# Altium schematic sheet MotorCTRL.SchDoc(MotorCTRL)
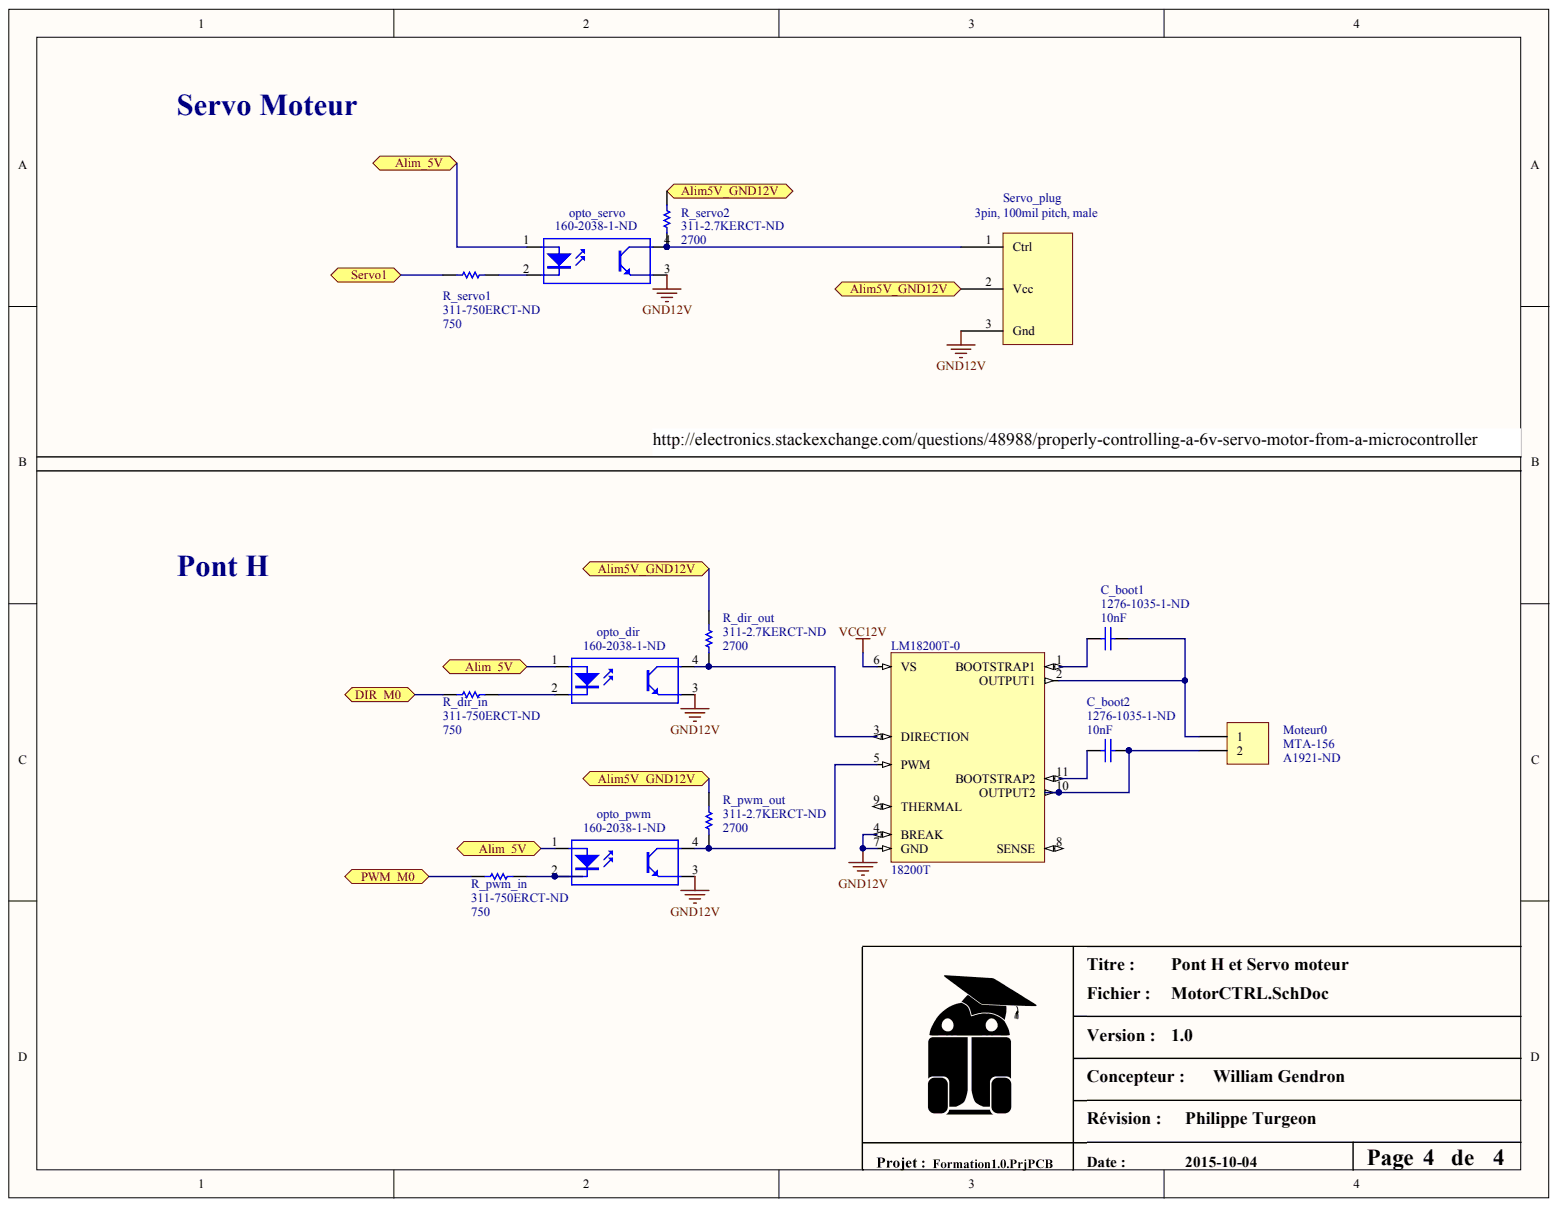
[diagram p0: MotorCTRL.SchDoc]

## Parts
C_boot1  value 10nF  Supplier Part Number 1: 1276-1035-1-ND  [c1206]  (hidden comment: 10nf - 1276-1035-1-ND)
C_boot2  value 10nF  Supplier Part Number 1: 1276-1035-1-ND  [c1206]  (hidden comment: 10nf - 1276-1035-1-ND)
LM18200T-0  18200T  [18200T]
Moteur0  A1921-ND  value MTA-156  [2PIN_396]
opto_dir  160-2038-1-ND  [Opto_simple]
opto_pwm  160-2038-1-ND  [Opto_simple]
opto_servo  160-2038-1-ND  [Opto_simple]
R_dir_in  value 750  Supplier Part Number 1: 311-750ERCT-ND  [8-1206]  (hidden comment: 220 ohms - 311-220ERCT-ND)
R_dir_out  value 2700  Supplier Part Number 1: 311-2.7KERCT-ND  [8-1206]  (hidden comment: 220 ohms - 311-220ERDKR-ND)
R_pwm_in  value 750  Supplier Part Number 1: 311-750ERCT-ND  [8-1206]  (hidden comment: 220 ohms - 311-220ERCT-ND)
R_pwm_out  value 2700  Supplier Part Number 1: 311-2.7KERCT-ND  [8-1206]  (hidden comment: 220ohms - 311-220ERDKR-ND)
R_servo1  value 750  [C1206]  (hidden comment: 220)
R_servo2  value 2700  Supplier part number 1: 311-2.7KERCT-ND  [C1206]  (hidden comment: 220)
Servo_plug  3pin, 100mil pitch, male  [ServoPin]

## Ports
ALIM5V_GND12V, ALIM_5V, DIR_M0, PWM_M0, SERVO1

## Nets
GND12V: LM18200T-0.4(BREAK) LM18200T-0.7(GND) opto_dir.3 opto_pwm.3 opto_servo.3 Servo_plug.3
VCC12V: LM18200T-0.6(VS)
DIR_M0: R_dir_in.1 ; port DIR_M0
PWM_M0: R_pwm_in.1 ; port PWM_M0
NetC_boot1_1: C_boot1.1 LM18200T-0.1(BOOTSTRAP1)
NetC_boot1_2: C_boot1.2 LM18200T-0.2(OUTPUT1) Moteur0.1
NetC_boot2_1: C_boot2.1 LM18200T-0.11(BOOTSTRAP2)
NetC_boot2_2: C_boot2.2 LM18200T-0.10(OUTPUT2) Moteur0.2
NetLM18200T-0_3: LM18200T-0.3(DIRECTION) opto_dir.4 R_dir_out.1
NetLM18200T-0_5: LM18200T-0.5(PWM) opto_pwm.4 R_pwm_out.2
Netopto_dir_1: opto_dir.1 opto_pwm.1 opto_servo.1 ; port ALIM_5V
Netopto_dir_2: opto_dir.2 R_dir_in.2
Netopto_pwm_2: opto_pwm.2 R_pwm_in.2
Netopto_servo_2: opto_servo.2 R_servo1.2
Netopto_servo_4: opto_servo.4 R_servo2.1 Servo_plug.1
NetR_dir_out_2: R_dir_out.2 R_pwm_out.1 R_servo2.2 Servo_plug.2 ; port ALIM5V_GND12V
NetR_servo1_1: R_servo1.1 ; port SERVO1

Not connected: LM18200T-0 8(SENSE) 9(THERMAL)

## Library data of the parts
C_boot1 C_boot2 = Cap: Capacitor; Component Kind: Standard; Library Name: Miscellaneous Devices.IntLib; Signal Integrity: Cap; Simulation: CAP; Supplier 1: Digi-Key
Moteur0 = Header 2H: Header, 2-Pin, Right Angle; Component Kind: Standard; Library Name: Miscellaneous Connectors.IntLib; Signal Integrity: Connector; Supplier 1: Digi-Key; Supplier Part Number 1: A1921-ND
opto_dir opto_pwm opto_servo = Optoisolator1: 4-Pin Phototransistor Optocoupler; Component Kind: Standard; Library Name: Miscellaneous Devices.IntLib; Supplier 1: Digi-Key; Supplier Part Number 1: 160-2038-1-ND
R_dir_in R_dir_out R_pwm_in R_pwm_out R_servo1 R_servo2 = Res1: Resistor; Component Kind: Standard; Library Name: Miscellaneous Devices.IntLib; Signal Integrity: Res; Simulation: RESISTOR; Supplier 1: Digi-Key
  R_servo1: Supplier part number 1: 311-750ERCT-ND
Servo_plug = Servo_plug: Component Kind: Standard; Library Name: servo_plug.SchLib; Supplier 1: Magasin Uni; Supplier Part Number 1: 3pin, 100mil pitch, male
LM18200T-0 = 18200T: Component Kind: Standard; Library Name: 18200T.SchLib; Supplier 1: Digi-Key; Supplier Part Number 1: LMD18200T/NOPB-ND
